# Altium schematic sheet J-Funchange.SchDoc(J-Funchange)
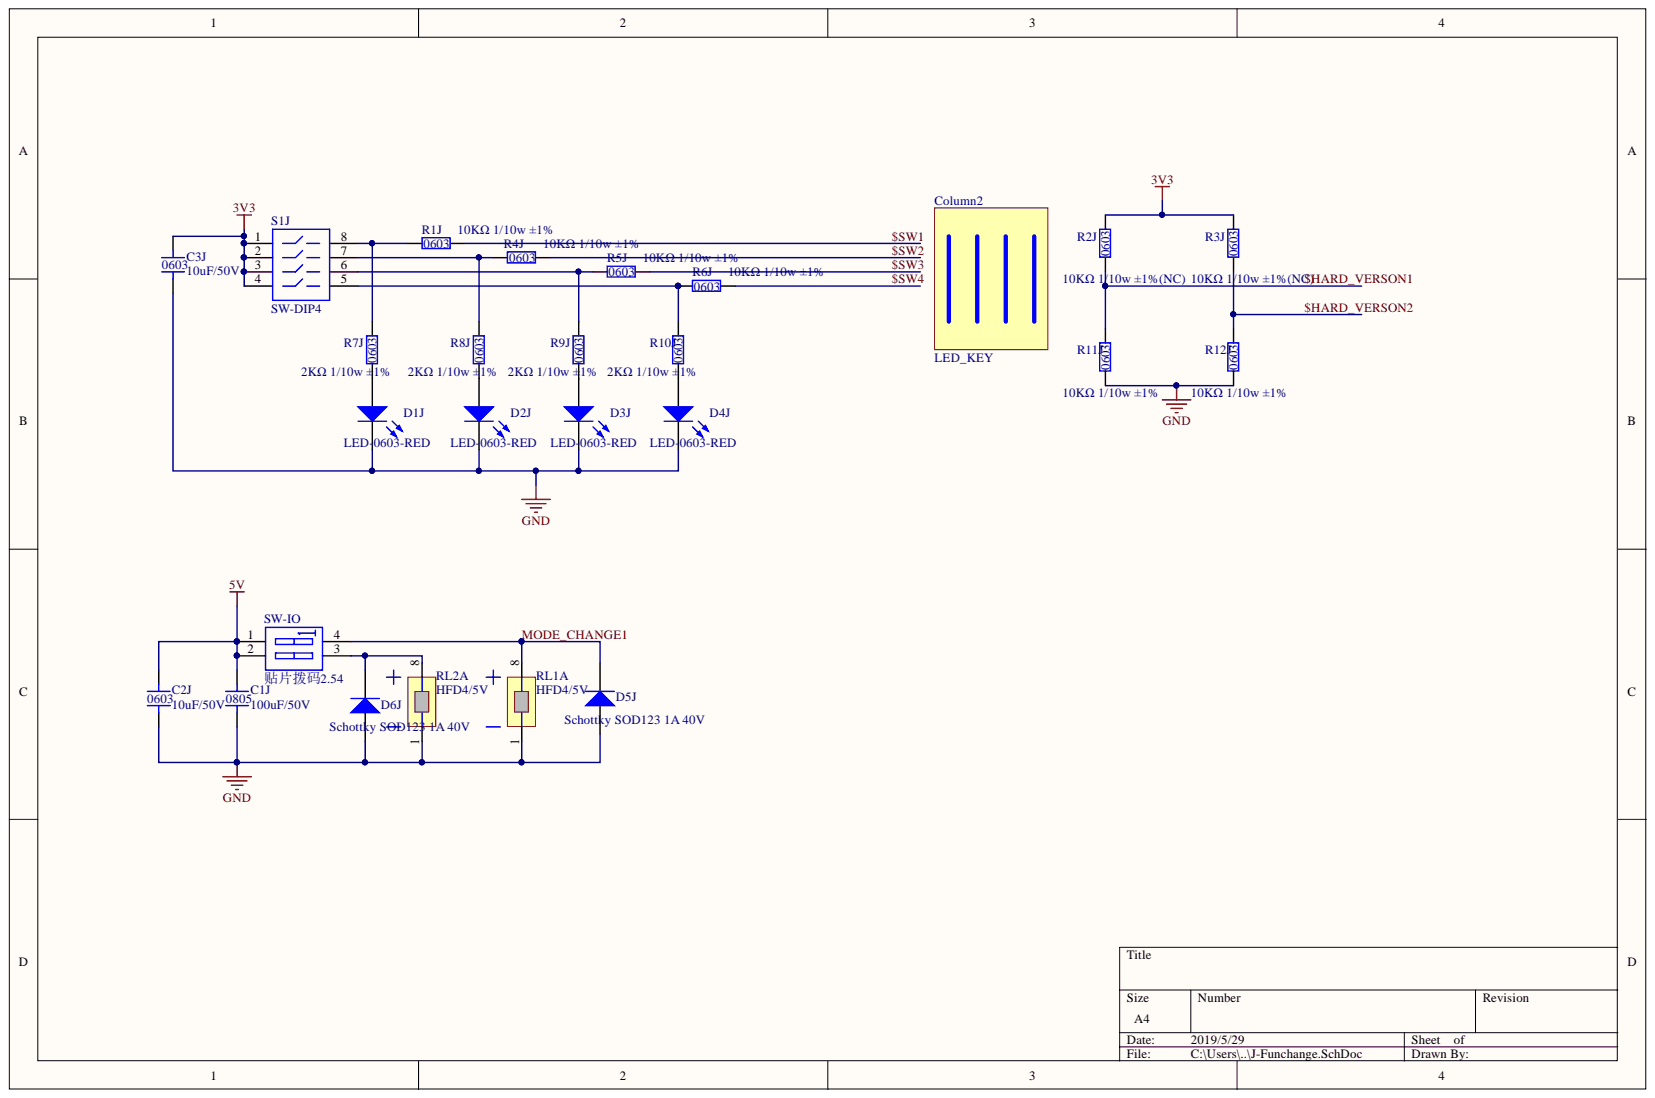
[diagram p0: J-Funchange.SchDoc]

## Parts
C1J  100uF/50V  [C-0805]
C2J  10uF/50V  [C-0603]
C3J  10uF/50V  [C-0603]
Column2  LED_KEY  [LPE4-5-19]
D1J  LED-0603-RED  [LED-0603]
D2J  LED-0603-RED  [LED-0603]
D3J  LED-0603-RED  [LED-0603]
D4J  LED-0603-RED  [LED-0603]
D5J  Schottky SOD123 1A 40V  [SOD-123]
D6J  Schottky SOD123 1A 40V  [SOD-123]
R1J  10KΩ 1/10w ±1%  [R-0603]
R2J  10KΩ 1/10w ±1%(NC)  [R-0603]
R3J  10KΩ 1/10w ±1%(NC)  [R-0603]
R4J  10KΩ 1/10w ±1%  [R-0603]
R5J  10KΩ 1/10w ±1%  [R-0603]
R6J  10KΩ 1/10w ±1%  [R-0603]
R7J  2KΩ 1/10w ±1%  [R-0603]
R8J  2KΩ 1/10w ±1%  [R-0603]
R9J  2KΩ 1/10w ±1%  [R-0603]
R10J  2KΩ 1/10w ±1%  [R-0603]
R11J  10KΩ 1/10w ±1%  [R-0603]
R12J  10KΩ 1/10w ±1%  [R-0603]
RL1  HFD4/5V  [HFD4]  (part A)
RL2  HFD4/5V  [HFD4]  (part A)
S1J  SW-DIP4  [拨码开关位]
SW-IO  贴片拨码2.54  [拨码开关2位]

## Nets
3V3: C3J.2 R2J.2 R3J.2 S1J.1 S1J.2 S1J.3 S1J.4
5V: C1J.2 C2J.2 SW-IO.1 SW-IO.2
GND: C1J.1 C2J.1 C3J.1 D1J.2 D2J.2 D3J.2 D4J.2 D5J.1 D6J.1 R11J.1 R12J.1 RL1.1 RL2.1
$HARD_VERSON1: R2J.1 R11J.2
$HARD_VERSON2: R3J.1 R12J.2
$SW1: R1J.2
$SW2: R4J.2
$SW3: R5J.2
$SW4: R6J.2
MODE_CHANGE1: D5J.2 RL1.8 SW-IO.4
NetD1J_1: D1J.1 R7J.1
NetD2J_1: D2J.1 R8J.1
NetD3J_1: D3J.1 R9J.1
NetD4J_1: D4J.1 R10J.1
NetD6J_2: D6J.2 RL2.8 SW-IO.3
NetR1J_1: R1J.1 R7J.2 S1J.8
NetR4J_1: R4J.1 R8J.2 S1J.7
NetR5J_1: R5J.1 R9J.2 S1J.6
NetR6J_1: R6J.1 R10J.2 S1J.5

## Library data of the parts
D1J D2J D3J D4J = LED3: Typical BLUE SiC LED; Component Kind: Standard; DatasheetVersion: 16-Apr-2002; LatestRevisionDate: 17-Dec-2003; LatestRevisionNote: PCB Footprint replaced.; Library Name: Miscellaneous Devices.IntLib; PackageDescription: SM LED; 2 C-Bend Leads; Body 3.5 x 2.8 x 1.9 mm, inc leads (LxWxH typ); PackageReference: 3.5X2.8X1.9; PackageVersion: 16-Apr-2002; Published: 8-Jun-2000; Signal Integrity: LED; Simulation: LED3; Publisher: Altium Limited
D5J D6J = Diode 1N4148: High Conductance Fast Diode; Code_JEDEC: DO-204-AH; Component Kind: Standard; ComponentLink1Description: Manufacturer Link; ComponentLink1URL: http://www.fairchildsemi.com/; ComponentLink2Description: Datasheet; ComponentLink2URL: http://www.fairchildsemi.com/ds/1N%2F1N4148.pdf; DatasheetVersion: 1997; LatestRevisionDate: 17-Jul-2002; LatestRevisionNote: Re-released for DXP Platform.; Library Name: Miscellaneous Devices.IntLib; PackageDescription: Diode, Axial; Body 3.8 x 1.9 mm (LxDia. max), Lead Dia. 0.53 mm (max); PackageReference: DO-35; Published: 8-Jun-2000; Signal Integrity: 1N4148; Simulation: 1N4148; Publisher: Altium Limited
R1J R2J R3J R4J R5J R6J R11J R12J = 2kΩ 1/10w ±1%: Component Kind: Standard; Library Name: dahuan.SchLib
S1J = SW-DIP4: DIP Switch; Component Kind: Standard; LatestRevisionDate: 17-Jul-2002; LatestRevisionNote: Re-released for DXP Platform.; Library Name: Miscellaneous Devices.IntLib; PackageReference: DIP-8; Published: 24-Mar-1999; Simulation: dpsw4; Publisher: Altium Limited
SW-IO = SW DIP-2: DIP Switch, 2 Position, SPST; Component Kind: Standard; LatestRevisionDate: 17-Jul-2002; LatestRevisionNote: Re-released for DXP Platform.; Library Name: Miscellaneous Devices.IntLib; PackageReference: DIP-4; Published: 24-Mar-1999; Simulation: dpsw2; Publisher: Altium Limited
C1J = 100uF/50V: Component Kind: Standard; Library Name: dahuan.SchLib
C2J C3J = 10uF/50V: Component Kind: Standard; Library Name: dahuan.SchLib
Column2 = LED_KEY: Component Kind: Standard; Library Name: dahuan.SchLib
R7J R8J R9J R10J = 2kΩ 1/10w ±1%: Component Kind: Standard; Library Name: dahuan.SchLib
RL1 RL2 = HFD4/5V: Component Kind: Standard; Library Name: dahuan.SchLib
